# Altium schematic sheet USB_UART.SchDoc(USB_UART)
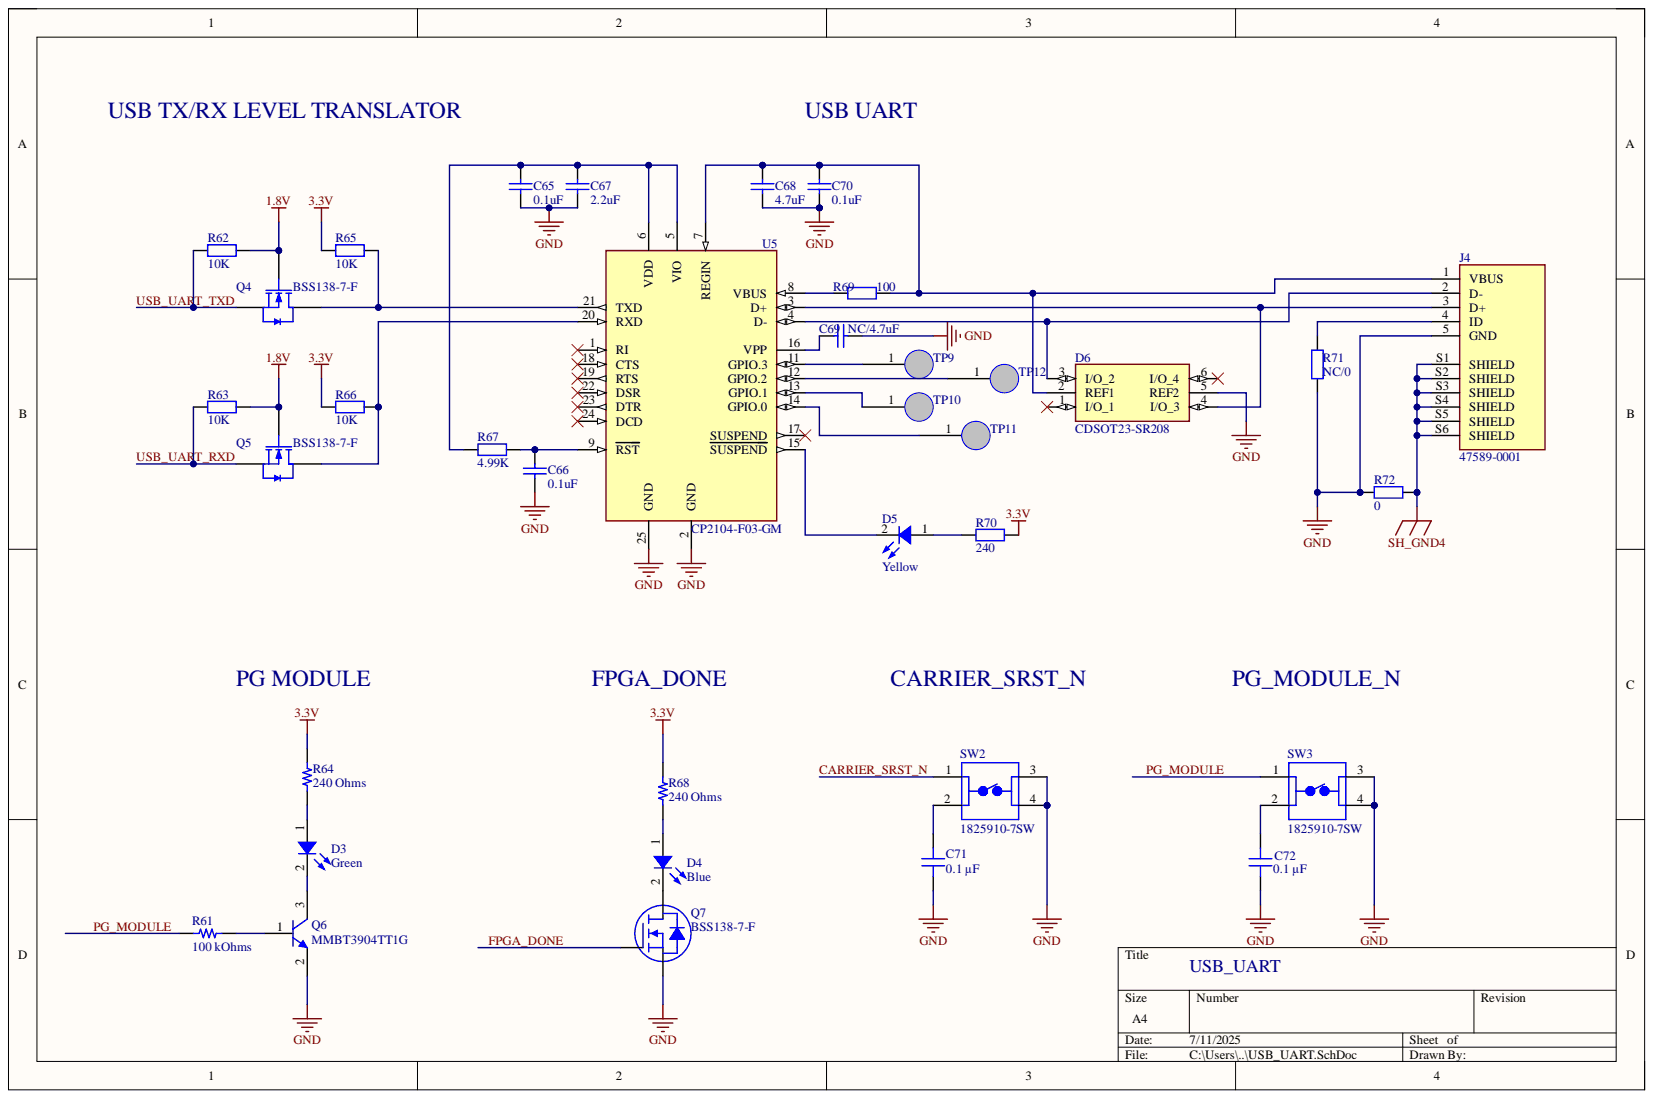
[diagram p0: USB_UART.SchDoc]

## Parts
C65  value 0.1uF  [0603_C]  (hidden comment: Cap)
C66  value 0.1uF  [0603_C]  (hidden comment: Cap)
C67  value 2.2uF  [0603_C]  (hidden comment: Cap)
C68  value 4.7uF  [0603_C]  (hidden comment: Cap)
C69  value NC/4.7uF  [0603_C]  (hidden comment: Cap)
C70  value 0.1uF  [0603_C]  (hidden comment: Cap)
C71  0.1 µF  [CAP 0603_1608]
C72  0.1 µF  [CAP 0603_1608]
D3  Green  [LED 0805_2012 GREEN]
D4  Blue  [LED 0603_1608 BLUE]
D5  Yellow  [LED 0603_1608 YELLOW]
D6  CDSOT23-SR208  [CDSOT23-SR208]
J4  47589-0001  [47589-0001]
Q4  BSS138-7-F  [SOT-23-3L]
Q5  BSS138-7-F  [SOT-23-3L]
Q6  MMBT3904TT1G  [ON SEMICONDUCTOR SC-75]
Q7  BSS138-7-F  [DIODES SOT-23-3]
R61  100 kOhms  [RES 0603_1608]
R62  value 10K  [0603_R]  (hidden comment: Res2)
R63  value 10K  [0603_R]  (hidden comment: Res2)
R64  240 Ohms  [RES 0603_1608]
R65  value 10K  [0603_R]  (hidden comment: Res2)
R66  value 10K  [0603_R]  (hidden comment: Res2)
R67  value 4.99K  [0603_R]  (hidden comment: Res2)
R68  240 Ohms  [RES 0603_1608]
R69  value 100  [0603_R]  (hidden comment: Res2)
R70  value 240  [0603_R]  (hidden comment: Res2)
R71  value NC/0  [0603_R]  (hidden comment: Res2)
R72  value 0  [0603_R]  (hidden comment: Res2)
SW2  1825910-7SW  [1825910-7SW]
SW3  1825910-7SW  [1825910-7SW]
TP9  TP  [TP]
TP10  TP  [TP]
TP11  TP  [TP]
TP12  TP  [TP]
U5  CP2104-F03-GM  [CP2104-F03-GM]

## Nets
1.8V: Q4.1 Q5.1 R62.1 R63.1
3.3V: R64.2 R65.2 R66.2 R68.2 R70.1
GND: C65.2 C66.2 C67.2 C68.2 C69.2 C70.2 C71.2 C72.1 D6.5(REF2) J4.5(GND) Q6.2 Q7.2 R71.2 R72.2 SW2.3 SW2.4 SW3.3 SW3.4 U5.2(GND) U5.25(GND)
SH_GND4: J4.S1(SHIELD) J4.S2(SHIELD) J4.S3(SHIELD) J4.S4(SHIELD) J4.S5(SHIELD) J4.S6(SHIELD) R72.1
CARRIER_SRST_N: SW2.1
FPGA_DONE: Q7.1
PG_MODULE: R61.1 SW3.1
USB_UART_RXD: Q5.2 R63.2
USB_UART_TXD: Q4.2 R62.2
NetC65_1: C65.1 C67.1 R67.2 U5.5(VIO) U5.6(VDD)
NetC66_1: C66.1 R67.1 U5.9(RST)
NetC68_1: C68.1 C70.1 D6.2(REF1) J4.1(VBUS) R69.1 U5.7(REGIN)
NetC69_1: C69.1 U5.16(VPP)
NetC71_1: C71.1 SW2.2
NetC72_2: C72.2 SW3.2
NetD3_1: D3.1 R64.1
NetD3_2: D3.2 Q6.3
NetD4_1: D4.1 R68.1
NetD4_2: D4.2 Q7.3
NetD5_1: D5.1 R70.2
NetD5_2: D5.2 U5.15(SUSPEND)
NetD6_3: D6.3(I/O_2) J4.2(D-) U5.4(D-)
NetD6_4: D6.4(I/O_3) J4.3(D+) U5.3(D+)
NetJ4_4: J4.4(ID) R71.1
NetQ4_3: Q4.3 R65.1 U5.21(TXD)
NetQ5_3: Q5.3 R66.1 U5.20(RXD)
NetQ6_1: Q6.1 R61.2
NetR69_2: R69.2 U5.8(VBUS)
NetTP9_1: TP9.1 U5.11(GPIO.3)
NetTP10_1: TP10.1 U5.13(GPIO.1)
NetTP11_1: TP11.1 U5.14(GPIO.0)
NetTP12_1: TP12.1 U5.12(GPIO.2)

Not connected: D6 1(I/O_1) 6(I/O_4); U5 1(RI) 17(SUSPEND) 18(CTS) 19(RTS) 22(DSR) 23(DTR) 24(DCD)

## Library data of the parts
C65-C70 = Cap: Capacitor; Component Kind: Standard; LatestRevisionDate: 17-Jul-2002; LatestRevisionNote: Re-released for DXP Platform.; Library Name: Miscellaneous Devices.IntLib; PackageDescription: Radial Cap, Thru-Hole; 2 Leads; 0.3 in Pin Spacing; PackageReference: RAD-0.3; Published: 8-Jun-2000; Signal Integrity: Cap; Simulation: CAP; Publisher: Altium Limited
C71 C72 = C0603C104K5RAC7411: CAP CER 0.1UF 50V X7R 0603; manufacturer: KEMET; Database Table Name: Capacitors - Ceramic - 0603; Tolerance: ±10%; Applications: Bypass, Decoupling; Capacitance: 0.1 µF; Component Kind: Standard; componentlink1description: Datasheet; componentlink1url: https://api.kemet.com/component-edge/download/datasheet/C0603C104K5RAC7411.pdf; componentlink2description: DigiKey Link; componentlink2url: https://www.digikey.com/en/products/detail/kemet/C0603C104K5RAC7411/3317013; Device Package: 0603 (1608 Metric); lastupdated: 2024/2/9 20:10:30; Library Name: Celestial Altium Library - altium_library.DbLib; Lifecycle Status: Active; Minimum Order: 1; Mounting Type: Surface Mount, MLCC; Operating Temperature: -55°C ~ 125°C; Package / Case: 0603 (1608 Metric); packaging: TapeAndReel; Part Number: C0603C104K5RAC7411; Part Status: Active; partid: 2233; price: 0.01; series: SMD Comm X7R; Size / Dimension: 0.063" L x 0.031" W (1.60mm x 0.80mm); Supplier 1: DigiKey; Supplier Part Number 1: 399-C0603C104K5RAC7411CT-ND; Temperature Coefficient: X7R; Thickness (Max): 0.035" (0.90mm); value: 0.1 µF; Voltage - Rated: 50V
D3 = LTST-C171TGKT: LED GREEN CLEAR CHIP SMD; Manufacturer: Lite-On Inc.; Configuration: Independent; Current - Test: 20mA; Database Table Name: LED - Indication; Lens Color: Colorless; Lens Size: 1.40mm x 1.25mm; Lens Style: Rectangle with Flat Top; Millicandela Rating: 260.5mcd; Viewing Angle: 130°; Voltage - Forward (Vf) (Typ): 3.2V; Wavelength - Dominant: 525nm; Wavelength - Peak: 530nm; Color: Green; Component Kind: Standard; ComponentLink1Description: Datasheet; ComponentLink1URL: http://optoelectronics.liteon.com/upload/download/DS22-2000-299/LTST-C171TGKT.pdf; ComponentLink2Description: DigiKey Link; ComponentLink2URL: http://digikey.com/product-detail/en/lite-on-inc/LTST-C171TGKT/160-2211-1-ND/7597452; Device Package: 0805 (2012 Metric); Height (Max): 0.90mm; LastUpdated: 2024/6/3 20:00:29; Lens Transparency: Clear; Library Name: Celestial Altium Library - altium_library.DbLib; Lifecycle Status: Active; Minimum Order: 1; Mounting Type: Surface Mount; Package / Case: 0805 (2012 Metric); Packaging: TapeAndReel; Part Number: LTST-C171TGKT; Part Status: Active; PartId: 273; Price: 0.14; Series: -; Size / Dimension: 2.00mm L x 1.25mm W; Supplier 1: DigiKey; Supplier Device Package: Chip LED; Supplier Part Number 1: 160-2211-1-ND; Value: Green
D4 = LTST-C193TBKT-5A: LED BLUE CLEAR CHIP SMD; Manufacturer: Lite-On Inc.; Configuration: Standard; Current - Test: 5mA; Database Table Name: LED - Indication; Lens Color: Colorless; Lens Size: 1.15mm x 0.80mm; Lens Style: Rectangle with Flat Top; Millicandela Rating: 15mcd; Viewing Angle: 130°; Voltage - Forward (Vf) (Typ): 2.8V; Wavelength - Dominant: 470nm; Wavelength - Peak: 468nm; Color: Blue; Component Kind: Standard; ComponentLink1Description: Datasheet; ComponentLink1URL: //media.digikey.com/pdf/Data%20Sheets/Lite-On%20PDFs/LTST-C193TBKT-5A.pdf; ComponentLink2Description: DigiKey Link; ComponentLink2URL: http://digikey.com/product-detail/en/lite-on-inc/LTST-C193TBKT-5A/160-1827-1-ND/2355044; Device Package: 0603 (1608 Metric); Height (Max): 0.45mm; LastUpdated: 2024/6/3 19:57:52; Lens Transparency: Clear; Library Name: Celestial Altium Library - altium_library.DbLib; Lifecycle Status: Active; Minimum Order: 1; Mounting Type: Surface Mount; Package / Case: 0603 (1608 Metric); Packaging: TapeAndReel; Part Number: LTST-C193TBKT-5A; Part Status: Active; PartId: 829; Price: 0.06; Series: -; Size / Dimension: 1.60mm L x 0.80mm W; Supplier 1: DigiKey; Supplier Device Package: Chip LED; Supplier Part Number 1: 160-1827-1-ND; Value: Blue
D5 = LTST-C190KSKT: LED YELLOW CLEAR CHIP SMD; Manufacturer: Lite-On Inc.; Configuration: Standard; Current - Test: 20mA; Database Table Name: LED - Indication; Lens Color: Colorless; Lens Size: 1.10mm x 0.80mm; Lens Style: Rectangle with Flat Top; Millicandela Rating: 104mcd; Viewing Angle: 130°; Voltage - Forward (Vf) (Typ): 2.1V; Wavelength - Dominant: 591nm; Wavelength - Peak: 588nm; Color: Yellow; Component Kind: Standard; ComponentLink1Description: Datasheet; ComponentLink1URL: http://optoelectronics.liteon.com/upload/download/DS-22-99-0189/LTST-C190KSKT.pdf; ComponentLink2Description: DigiKey Link; ComponentLink2URL: http://digikey.com/product-detail/en/lite-on-inc/LTST-C190KSKT/160-1437-1-ND/386818; Device Package: 0603 (1608 Metric); Height (Max): 0.90mm; LastUpdated: 2024/6/3 20:01:09; Lens Transparency: Clear; Library Name: Celestial Altium Library - altium_library.DbLib; Lifecycle Status: Active; Minimum Order: 1; Mounting Type: Surface Mount; Package / Case: 0603 (1608 Metric); Packaging: TapeAndReel; Part Number: LTST-C190KSKT; Part Status: Active; PartId: 134; Price: 0.05; Series: -; Size / Dimension: 1.60mm L x 0.80mm W; Supplier 1: DigiKey; Supplier Device Package: Chip LED; Supplier Part Number 1: 160-1437-1-ND; Value: Yellow
Q4 Q5 = AO3400A N道沟 X0YV1T SOT-23: MOS; Component Kind: Standard; ComponentLink1Description: 供应商链接; ComponentLink1URL: http://www.szlcsc.com/product/details_21629.html; Library Name: Miscellaneous Devices LC.IntLib; Package: SOT-23; Supplier: LC; SuppliersPartNumber: C20917
Q6 = MMBT3904TT1G: TRANS NPN 40V 0.2A SOT416; Manufacturer: ON Semiconductor; Current - Collector (Ic) (Max): 200mA; Database Table Name: Transistors - Bipolar BJT - Single; DC Current Gain (hFE) (Min) @ Ic, Vce: 100 @ 10mA, 1V; Frequency - Transition: 300MHz; Power - Max: 300mW; Vce Saturation (Max) @ Ib, Ic: 300mV @ 5mA, 50mA; Component Kind: Standard; ComponentLink1Description: Datasheet; ComponentLink1URL: https://www.onsemi.com/pub/Collateral/MMBT3904TT1-D.PDF; ComponentLink2Description: DigiKey Link; ComponentLink2URL: http://digikey.com/product-detail/en/on-semiconductor/MMBT3904TT1G/MMBT3904TT1GOSCT-ND/1139815; LastUpdated: 2024/6/3 20:24:07; Library Name: Celestial Altium Library - altium_library.DbLib; Lifecycle Status: Active; Minimum Order: 1; Mounting Type: Surface Mount; Operating Temperature: -55°C ~ 150°C (TJ); Package / Case: SC-75, SOT-416; Packaging: TapeAndReel; Part Number: MMBT3904TT1G; Part Status: Active; PartId: 34; Price: 0.02; Series: -; Supplier 1: DigiKey; Supplier Device Package: SC-75, SOT-416; Supplier Part Number 1: MMBT3904TT1GOSCT-ND; Transistor Type: NPN; Voltage - Collector Emitter Breakdown (Max): 40V
Q7 = BSS138-7-F: MOSFET N-CH 50V 200MA SOT23-3; Manufacturer: Diodes Incorporated; Current - Continuous Drain (Id) @ 25°C: 200mA (Ta); Database Table Name: MOSFET - Single - N-Ch; Drive Voltage (Max Rds On,  Min Rds On): 10V; FET Type: N-Channel; Input Capacitance (Ciss) (Max) @ Vds: 50 pF @ 10 V; Power Dissipation (Max): 300mW (Ta); Rds On (Max) @ Id, Vgs: 3.5Ohm @ 220mA, 10V; Vgs (Max): ±20V; Vgs(th) (Max) @ Id: 1.5V @ 250µA; Component Kind: Standard; ComponentLink1Description: Datasheet; ComponentLink1URL: https://www.diodes.com/assets/Datasheets/ds30144.pdf; ComponentLink2Description: DigiKey Link; ComponentLink2URL: https://www.digikey.com/en/products/detail/diodes-incorporated/BSS138-7-F/717723; Drain to Source Voltage (Vdss): 50 V; LastUpdated: 2024/6/3 20:15:18; Library Name: Celestial Altium Library - altium_library.DbLib; Lifecycle Status: Active; Minimum Order: 1; Mounting Type: Surface Mount; Operating Temperature: -55°C ~ 150°C (TJ); Package / Case: TO-236-3, SC-59, SOT-23-3; Packaging: TapeAndReel; Part Number: BSS138-7-F; Part Status: Active; Price: 0.02; Series: -; Supplier 1: DigiKey; Supplier Device Package: SOT-23-3; Supplier Part Number 1: BSS138-FDICT-ND; Technology: MOSFET (Metal Oxide)
R61 = RNCS0603BKE100K: RES 100K OHM 0.1% 1/16W 0603; Manufacturer: Stackpole Electronics Inc; Database Table Name: Resistors - Surface Mount - 0603; Power (Watts): 0.063W, 1/16W; Temperature Coefficient: ±25ppm/°C; Component Kind: Standard; ComponentLink1Description: Datasheet; ComponentLink1URL: https://www.seielect.com/catalog/sei-rncs_rnch.pdf; ComponentLink2Description: DigiKey Link; ComponentLink2URL: https://www.digikey.com/en/products/detail/stackpole-electronics-inc/RNCS0603BKE100K/1708835; Composition: Thin Film; Device Package: 0603 (1608 Metric); Features: Anti-Corrosive, Automotive AEC-Q200, Moisture Resistant; Height - Seated (Max): 0.022" (0.55mm); LastUpdated: 2024/2/9 20:43:37; Library Name: Celestial Altium Library - altium_library.DbLib; Lifecycle Status: Active; Minimum Order: 1; Number of Terminations: 2; Operating Temperature: -55°C ~ 155°C; Package / Case: 0603 (1608 Metric); Packaging: TapeAndReel; Part Number: RNCS0603BKE100K; Part Status: Active; PartId: 4849; Price: 0.06; Ratings: AEC-Q200; Resistance: 100 kOhms; Series: RNCS; Size / Dimension: 0.061" L x 0.031" W (1.55mm x 0.80mm); Supplier 1: DigiKey; Supplier Device Package: 0603; Supplier Part Number 1: RNCS0603BKE100KCT-ND; Tolerance: ±0.1%; Value: 100 kOhms
R62 R63 R65-R67 R69-R72 = Res2: Resistor; Component Kind: Standard; LatestRevisionDate: 17-Jul-2002; LatestRevisionNote: Re-released for DXP Platform.; Library Name: Miscellaneous Devices.IntLib; PackageDescription: Axial Device, Thru-Hole; 2 Leads; 0.4 in Pin Spacing; PackageReference: AXIAL-0.4; Published: 8-Jun-2000; Signal Integrity: Res; Simulation: RESISTOR; Publisher: Altium Limited
R64 R68 = RMCF0603JT240R: RES 240 OHM 5% 1/10W 0603; Manufacturer: Stackpole Electronics Inc; Database Table Name: Resistors - Surface Mount - 0603; Power (Watts): 0.1W, 1/10W; Temperature Coefficient: ±200ppm/°C; Component Kind: Standard; ComponentLink1Description: Datasheet; ComponentLink1URL: https://www.seielect.com/catalog/sei-rmcf_rmcp.pdf; ComponentLink2Description: DigiKey Link; ComponentLink2URL: https://www.digikey.com/en/products/detail/stackpole-electronics-inc/RMCF0603JT240R/1712210; Composition: Thick Film; Device Package: 0603 (1608 Metric); Features: Automotive AEC-Q200; Height - Seated (Max): 0.022" (0.55mm); LastUpdated: 2024/2/9 20:43:32; Library Name: Celestial Altium Library - altium_library.DbLib; Lifecycle Status: Active; Minimum Order: 1; Number of Terminations: 2; Operating Temperature: -55°C ~ 155°C; Package / Case: 0603 (1608 Metric); Packaging: CutTape; Part Number: RMCF0603JT240R; Part Status: Active; PartId: 5995; Price: 0.01; Ratings: AEC-Q200; Resistance: 240 Ohms; Series: RMCF; Size / Dimension: 0.061" L x 0.031" W (1.55mm x 0.80mm); Supplier 1: DigiKey; Supplier Device Package: 0603; Supplier Part Number 1: RMCF0603JT240RCT-ND; Tolerance: ±5%; Value: 240 Ohms
D6 = CDSOT23-SR208: Component Kind: Standard; Library Name: USB_UART.SCHLIB
J4 = 47589-0001: Component Kind: Standard; Library Name: PCB.SchLib
SW2 SW3 = 1825910-7SW: Component Kind: Standard; Library Name: PCB.SchLib
TP9-TP12 = TP: Component Kind: Standard; Library Name: PCB.SchLib
U5 = CP2104-F03-GM: Component Kind: Standard; Library Name: USB_UART.SCHLIB
